# Altium schematic sheet Buck.SchDoc(Buck)
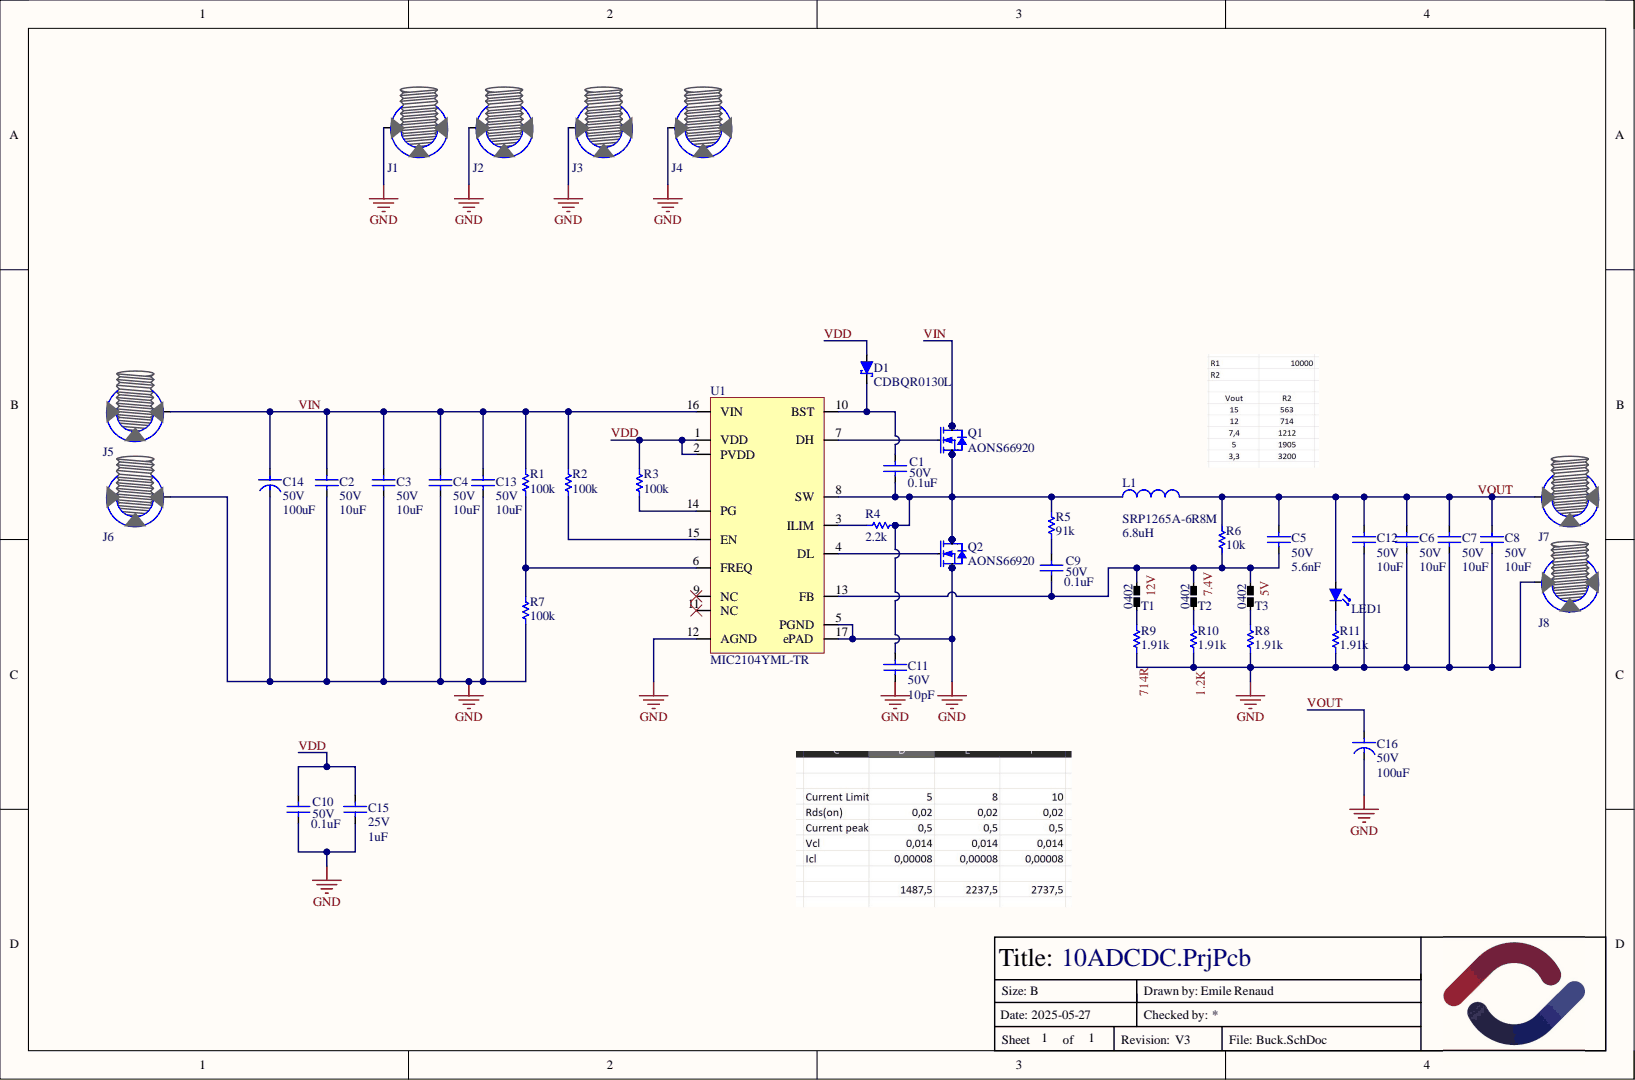
[diagram p0: Buck.SchDoc]

## Parts
C1  value 0.1uF  Volt: 50V  [CAPACITOR-0603]  (hidden comment: Capacitor-0603-0.1uF-50V-X7R)
C2  value 10uF  Volt: 50V  [CAPACITOR-1206]  (hidden comment: Capacitor-1206-10uF-50V-X5R)
C3  value 10uF  Volt: 50V  [CAPACITOR-1206]  (hidden comment: Capacitor-1206-10uF-50V-X5R)
C4  value 10uF  Volt: 50V  [CAPACITOR-1206]  (hidden comment: Capacitor-1206-10uF-50V-X5R)
C5  value 5.6nF  Volt: 50V  [CAPACITOR-0603]  (hidden comment: Capacitor-0603-0.1uF-50V-X7R)
C6  value 10uF  Volt: 50V  [CAPACITOR-1206]  (hidden comment: Capacitor-1206-10uF-50V-X5R)
C7  value 10uF  Volt: 50V  [CAPACITOR-1206]  (hidden comment: Capacitor-1206-10uF-50V-X5R)
C8  value 10uF  Volt: 50V  [CAPACITOR-1206]  (hidden comment: Capacitor-1206-10uF-50V-X5R)
C9  value 0.1uF  Volt: 50V  [CAPACITOR-0603]  (hidden comment: Capacitor-0603-0.1uF-50V-X7R)
C10  value 0.1uF  Volt: 50V  [CAPACITOR-0603]  (hidden comment: Capacitor-0603-0.1uF-50V-X7R)
C11  value 10pF  Volt: 50V  [CAPACITOR-0603]  (hidden comment: Capacitor-0603-10pF-50V-C0G)
C12  value 10uF  Volt: 50V  [CAPACITOR-1206]  (hidden comment: Capacitor-1206-10uF-50V-X5R)
C13  value 10uF  Volt: 50V  [CAPACITOR-1206]  (hidden comment: Capacitor-1206-10uF-50V-X5R)
C14  value 100uF  Volt: 50V  [CAP_J-V_8X10P5_RUB]  (hidden comment: CAP ALUM 100UF 20% 25V SMD)
C15  value 1uF  Volt: 25V  [CAPACITOR-0603]  (hidden comment: Capacitor-0603-1uF-25V-X5R)
C16  value 100uF  Volt: 50V  [CAP_J-V_8X10P5_RUB]  (hidden comment: CAP ALUM 100UF 20% 25V SMD)
D1  CDBQR0130L  [Diode-0402]
J1  hole  [HOLE-M2-Bolt]  (value not drawn)  (no BOM)
J2  hole  [HOLE-M2-Bolt]  (value not drawn)  (no BOM)
J3  hole  [HOLE-M2-Bolt]  (value not drawn)  (no BOM)
J4  hole  [HOLE-M2-Bolt]  (value not drawn)  (no BOM)
J5  hole  [HOLE-M2-Bolt]  (value not drawn)  (no BOM)
J6  hole  [HOLE-M2-Bolt]  (value not drawn)  (no BOM)
J7  hole  [HOLE-M2-Bolt]  (value not drawn)  (no BOM)
J8  hole  [HOLE-M2-Bolt]  (value not drawn)  (no BOM)
L1  SRP1265A-6R8M  value 6.8uH  [IND_SRP1265A-6R8M]
LED1  3147-B1931NB--20C007614U1930CT-ND  [LED-0603-2PIN]  (value not drawn)
Q1  AONS66920  [DFN-8-5x6-Power]
Q2  AONS66920  [DFN-8-5x6-Power]
R1  value 100k  [Resistor-0603]  (hidden comment: SMT resistor)
R2  value 100k  [Resistor-0603]  (hidden comment: SMT resistor)
R3  value 100k  [Resistor-0603]  (hidden comment: SMT resistor)
R4  value 2.2k  [Resistor-0603]  (hidden comment: SMT resistor)
R5  value 91k  [Resistor-0603]  (hidden comment: SMT resistor)
R6  value 10k  [Resistor-0603]  (hidden comment: SMT resistor)
R7  value 100k  [Resistor-0603]  (hidden comment: SMT resistor)
R8  value 1.91k  [Resistor-0603]  (hidden comment: SMT resistor)
R9  value 1.91k  [Resistor-0603]  (hidden comment: SMT resistor)
R10  value 1.91k  [Resistor-0603]  (hidden comment: SMT resistor)
R11  value 1.91k  [Resistor-0603]  (hidden comment: SMT resistor)
T1  NetTie_0402  [NetTie-0402]  (value not drawn)
T2  NetTie_0402  [NetTie-0402]  (value not drawn)
T3  NetTie_0402  [NetTie-0402]  (value not drawn)
U1  MIC2104YML-TR  [VQFN-16 3x3]

## Nets
GND: C2.1 C3.1 C4.1 C6.1 C7.1 C8.1 C10.1 C11.1 C12.1 C13.1 C14.2 C15.1 C16.2 J1.1 J2.1 J3.1 J4.1 J6.1 J8.1 Q2.1 Q2.2 Q2.3 R7.1 R8.1 R9.1 R10.1 R11.1 U1.5(PGND) U1.12(AGND) U1.17(ePAD)
VDD: C10.2 C15.2 D1.1 R3.2 U1.1(VDD) U1.2(PVDD)
VIN: C2.2 C3.2 C4.2 C13.2 C14.1 J5.1 Q1.5 Q1.6 Q1.7 Q1.8 R1.2 R2.2 U1.16(VIN)
VOUT: C5.2 C6.2 C7.2 C8.2 C12.2 C16.1 J7.1 L1.2 LED1.2 R6.2
NetC1_1: C1.1 C11.2 L1.1 Q1.1 Q1.2 Q1.3 Q2.5 Q2.6 Q2.7 Q2.8 R4.2 R5.2 U1.8(SW)
NetC1_2: C1.2 D1.2 U1.10(BST)
NetC5_1: C5.1 C9.1 R6.1 T1.1 T2.1 T3.1 U1.13(FB)
NetC9_2: C9.2 R5.1
NetLED1_1: LED1.1 R11.2
NetQ1_4: Q1.4 U1.7(DH)
NetQ2_4: Q2.4 U1.4(DL)
NetR1_1: R1.1 R7.2 U1.6(FREQ)
NetR2_1: R2.1 U1.15(EN)
NetR3_1: R3.1 U1.14(PG)
NetR4_1: R4.1 U1.3(ILIM)
NetR8_2: R8.2 T3.2
NetR9_2: R9.2 T1.2
NetR10_2: R10.2 T2.2

Not connected: U1 9(NC) 11(NC)

## Library data of the parts
C1 C9 C10 = Capacitor-0603-0.1uF-50V-X7R: Precision: X7R-10%; Component Kind: Standard; Description: Capacitor-0603-0.1uF-50V-X7R; Library Name: Capacitors.SchLib; Mounted: Yes; Package / Case: Metric 1608, EIA 0603; Supplier 1: Digi-Key; Supplier 2: Digi-Key; Supplier Part Number 1: 1276-1935-2-ND; Supplier Part Number 2: 1276-1934-2-ND
C2-C4 C6-C8 C12 C13 = Capacitor-1206-10uF-50V-X5R: Precision: X5R-10%; Component Kind: Standard; Description: Capacitor-1206-10uF-50V-X5R; Library Name: Capacitors.SchLib; Mounted: Yes; Package / Case: Metric 3216, EIA 1206; Supplier 1: Digi-Key; Supplier 2: Digi-Key; Supplier Part Number 1: 1276-2876-1-ND
C5 = Capacitor-0603-5.6nF-50V-X7R: Precision: X7R-10%; Component Kind: Standard; Description: Capacitor-0603-5.6nF-50V-X7R; Library Name: Capacitors.SchLib; Mounted: Yes; Package / Case: Metric 1608, EIA 0603; Supplier 1: Digi-Key; Supplier 2: Digi-Key; Supplier Part Number 1: 311-4100-1-ND
C11 = Capacitor-0603-10pF-50V-C0G: 10 pF ±5% 50V Ceramic Capacitor C0G 0603 (1608 Metric); Precision: X5R-10%; Component Kind: Standard; Library Name: Capacitors.SchLib; Mounted: Yes; Package / Case: Metric 1608 , EIA 0603; Supplier 1: Digikey; Supplier Part Number 1: 311-1058-1-ND
C14 C16 = Capacitor-100uF-50V-SMD: CAP ALUM 100UF 20% 50V SMD; Component Kind: Standard; Library Name: Capacitors-Electrolytic.SchLib; Mounted: Yes; Precision: 20%; Supplier 1: Digi-Key; Supplier 2: Digi-Key; Supplier Part Number 1: 1189-1651-1-ND
C15 = Capacitor-0603-1uF-25V-X5R: Precision: X5R-10%; Component Kind: Standard; Description: Capacitor-0603-1uF-25V-X5R; Library Name: Capacitors.SchLib; Mounted: Yes; Package / Case: Metric 1608, EIA 0603; Supplier 1: Digi-Key; Supplier 2: Digi-Key; Supplier Part Number 1: 1276-1859-2-ND; Supplier Part Number 2: 1276-1942-2-ND
D1 = CDBQR0130L: Diode SCHOTTKY  30 V 100mA Surface Mount 0402/SOD-923F; Volt: 30; Component Kind: Standard; Library Name: diodes.SchLib; Mounted: Yes; Package / Case: 0402/SOD-923F; Supplier 1: Digi-Key; Supplier Part Number 1: 641-1271-1-ND
J1-J8 = Hole 2.3mm M2: Hole for M2 Bolt with 4mm pad; Library Name: JumpersAndHoles.SchLib; Mounted: N/A
L1 = SRP1265A-6R8M: 6.8 µH Shielded Drum Core, Wirewound Inductor 11.5 A 11.5mOhm Max Nonstandard; Current: 11.5A; Component Kind: Standard; HelpURL: https://www.bourns.com/docs/Product-Datasheets/SRP1265A.pdf; Library Name: Inductors.SchLib; Mounted: Yes; Package / Case: SMD; Supplier 1: Digi-Key; Supplier Part Number 1: SRP1265A-6R8MCT-ND
LED1 = LED_BLUE: LED BLUE CLEAR 0603 SMD; Component Kind: Standard; Library Name: LEDs.SchLib; Mounted: Yes; Package / Case: 0603 (1608 Metric); Supplier 1: Digi-Key; Supplier Part Number 1: 3147-B1931NB--20C007614U1930CT-ND
Q1 Q2 = AONS66920: N-Channel 100 V 17.5A (Ta), 48A (Tc) 5W (Ta), 56.5W (Tc) Surface Mount 8-DFN (5x6); Current: 17.5A/48A; Volt: 60V; Component Kind: Standard; Help URL: https://cms.aosmd.com/api/product/2026/combine-support-documents?resources=2241,4431,4739,6665,6939; Library Name: FET-N-Small.SchLib; Mounted: Yes; Package / Case: 8-DFN-EP (3x3); Supplier 1: Digi-Key; Supplier Part Number 1: 785-1843-1-ND
R1-R3 R7 = Resistor-0603-100k: Resistor0603-100k; Precision: 1%; Watt: 0.1W; Component Kind: Standard; Library Name: Resistors.SchLib; Mounted: Yes; Package / Case: Metric 1608, EIA 0603; Supplier 1: Digi-Key; Supplier Part Number 1: 311-100KHRCT-ND
R4 = Resistor-0603-2.2k: Resistor0603-2.2k; Precision: 1%; Watt: 0.1W; Component Kind: Standard; Library Name: Resistors.SchLib; Mounted: Yes; Package / Case: Metric 1608, EIA 0603; Supplier 1: Digi-Key; Supplier Part Number 1: 311-2.20KHRCT-ND
R5 = Resistor-0603-91k: Resistor0603-91k; Precision: 1%; Watt: 0.1W; Component Kind: Standard; Library Name: Resistors.SchLib; Mounted: Yes; Package / Case: Metric 1608, EIA 0603; Supplier 1: Digi-Key; Supplier Part Number 1: 311-91.0KHRCT-ND
R6 = Resistor-0603-10k: Resistor0603-10k; Precision: 1%; Watt: 0.1W; Component Kind: Standard; Library Name: Resistors.SchLib; Mounted: Yes; Package / Case: Metric 1608, EIA 0603; Supplier 1: Digi-Key; Supplier Part Number 1: 311-10.0KHRTR-ND
R8-R11 = Resistor-0603-1.91k: Resistor0603-1.91k; Precision: 1%; Watt: 0.1W; Component Kind: Standard; Library Name: Resistors.SchLib; Mounted: Yes; Package / Case: Metric 1608, EIA 0603; Supplier 1: Digi-Key; Supplier Part Number 1: 311-1.91KHRCT-ND
U1 = MIC2104YML-TR: Buck Regulator Positive Output Step-Down DC-DC Controller IC 16-MLF® (3x3); Grade: None; Component Kind: Standard; HelpURL: https://ww1.microchip.com/downloads/aemDocuments/documents/OTH/ProductDocuments/DataSheets/MIC2103_4-75V-Synchronous-Buck-Controllers-Featuring-Adaptive-ON-Time-Control-DS20005899B.pdf; Library Name: PMIC-VoltageRegulators-DCDCSwitchingRegulators.SchLib; Mounted: Yes; Package / Case: 16-VFQFN Exposed Pad; Supplier 1: Digi-Key; Supplier Part Number 1: 576-4157-1-ND
T1-T3 = NetTie_0402: Component Kind: Standard; Library Name: JumpersAndHoles.SchLib
